# Altium schematic sheet eps_pv.SchDoc
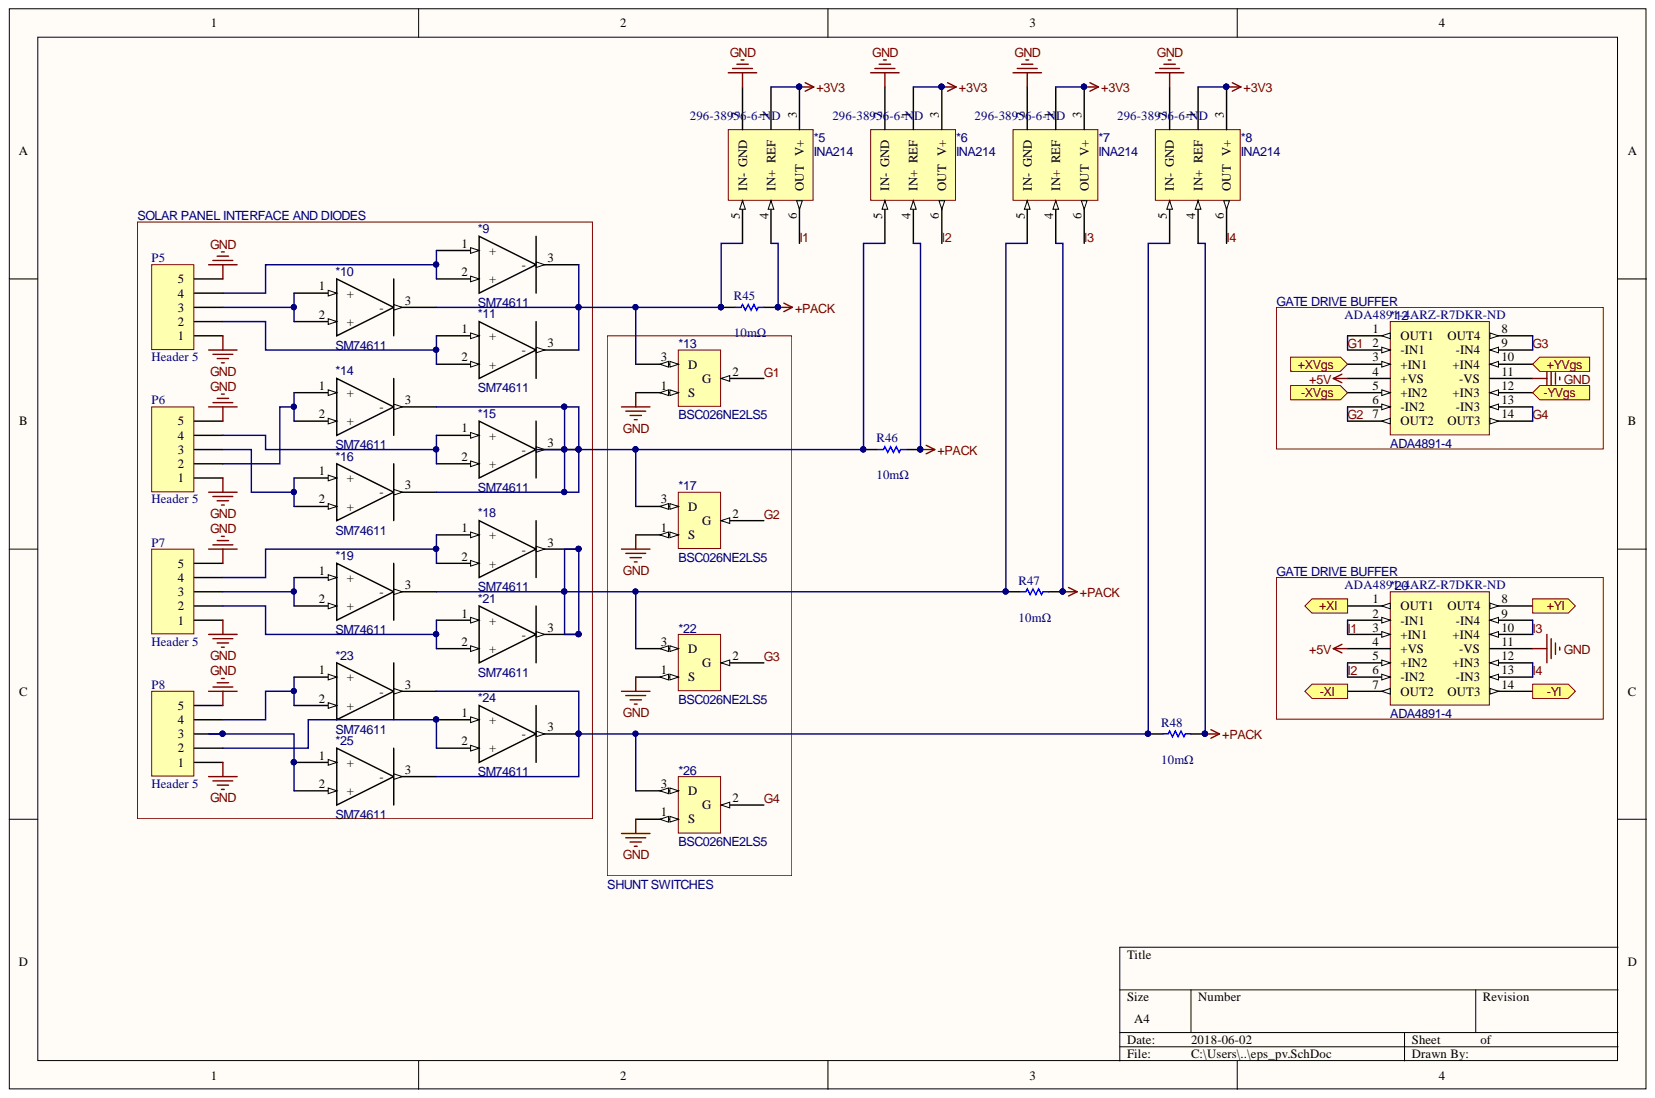
[diagram p0: eps_pv.SchDoc]

## Parts
*5  INA214  Supplier Part Number 1: 296-38956-6-ND  [SOT65P210X110-6N]
*6  INA214  Supplier Part Number 1: 296-38956-6-ND  [SOT65P210X110-6N]
*7  INA214  Supplier Part Number 1: 296-38956-6-ND  [SOT65P210X110-6N]
*8  INA214  Supplier Part Number 1: 296-38956-6-ND  [SOT65P210X110-6N]
*9  SM74611  [TO254P1435X464-3N]
*10  SM74611  [TO254P1435X464-3N]
*11  SM74611  [TO254P1435X464-3N]
*12  ADA4891-4  Supplier Part Number 1: ADA4891-4ARZ-R7DKR-ND  [R_14]
*13  BSC026NE2LS5  [INF-PG-TDSON-8-1_V]
*14  SM74611  [TO254P1435X464-3N]
*15  SM74611  [TO254P1435X464-3N]
*16  SM74611  [TO254P1435X464-3N]
*17  BSC026NE2LS5  [INF-PG-TDSON-8-1_V]
*18  SM74611  [TO254P1435X464-3N]
*19  SM74611  [TO254P1435X464-3N]
*20  ADA4891-4  Supplier Part Number 1: ADA4891-4ARZ-R7DKR-ND  [R_14]
*21  SM74611  [TO254P1435X464-3N]
*22  BSC026NE2LS5  [INF-PG-TDSON-8-1_V]
*23  SM74611  [TO254P1435X464-3N]
*24  SM74611  [TO254P1435X464-3N]
*25  SM74611  [TO254P1435X464-3N]
*26  BSC026NE2LS5  [INF-PG-TDSON-8-1_V]
P5  Header 5  [DF14-4P-1.25H(55) - duplicate]
P6  Header 5  [DF14-4P-1.25H(55) - duplicate]
P7  Header 5  [DF14-4P-1.25H(55) - duplicate]
P8  Header 5  [DF14-4P-1.25H(55) - duplicate]
R45  value 10mΩ  [1608[0603]]  (hidden comment: Res1)
R46  value 10mΩ  [1608[0603]]  (hidden comment: Res1)
R47  value 10mΩ  [1608[0603]]  (hidden comment: Res1)
R48  value 10mΩ  [1608[0603]]  (hidden comment: Res1)

## Ports
+XI, +XVgs, +YI, +YVgs, -XI, -XVgs, -YI, -YVgs

## Nets
+3V3: *5.1(REF) *5.3(V+) *6.1(REF) *6.3(V+) *7.1(REF) *7.3(V+) *8.1(REF) *8.3(V+)
+5V: *12.4(+VS) *20.4(+VS)
+PACK: *5.4(IN+) *6.4(IN+) *7.4(IN+) *8.4(IN+) R45.2 R46.2 R47.2 R48.2
GND: *5.2(GND) *6.2(GND) *7.2(GND) *8.2(GND) *12.11(-VS) *13.1 *17.1 *20.11(-VS) *22.1 *26.1 P5.1 P5.5 P6.1 P6.5 P7.1 P7.5 P8.1 P8.5
G1: *12.1(OUT1) *12.2(-IN1) *13.2
G2: *12.6(-IN2) *12.7(OUT2) *17.2
G3: *12.8(OUT4) *12.9(-IN4) *22.2
G4: *12.13(-IN3) *12.14(OUT3) *26.2
I1: *5.6(OUT) *20.2(-IN1) *20.3(+IN1)
I2: *6.6(OUT) *20.5(+IN2) *20.6(-IN2)
I3: *7.6(OUT) *20.9(-IN4) *20.10(+IN4)
I4: *8.6(OUT) *20.12(+IN3) *20.13(-IN3)
Net*5_5: *5.5(IN-) *9.3 *10.3 *11.3 *13.3 R45.1
Net*6_5: *6.5(IN-) *14.3 *15.3 *16.3 *17.3 R46.1
Net*7_5: *7.5(IN-) *18.3 *19.3 *21.3 *22.3 R47.1
Net*8_5: *8.5(IN-) *23.3 *24.3 *25.3 *26.3 R48.1
Net*9_1: *9.1 *9.2 P5.4
Net*10_1: *10.1 *10.2 P5.3
Net*11_1: *11.1 *11.2 P5.2
Net*12_3: *12.3(+IN1)
Net*12_5: *12.5(+IN2)
Net*12_10: *12.10(+IN4)
Net*12_12: *12.12(+IN3)
Net*14_1: *14.1 *14.2 P6.2
Net*15_1: *15.1 *15.2 P6.4
Net*16_1: *16.1 *16.2 P6.3
Net*18_1: *18.1 *18.2 P7.4
Net*19_1: *19.1 *19.2 P7.3
Net*20_1: *20.1(OUT1)
Net*20_7: *20.7(OUT2)
Net*20_8: *20.8(OUT4)
Net*20_14: *20.14(OUT3)
Net*21_1: *21.1 *21.2 P7.2
Net*23_1: *23.1 *23.2 P8.4
Net*24_1: *24.1 *24.2 P8.2
Net*25_1: *25.1 *25.2 P8.3

## Library data of the parts
*5-*8 = INA214: Current monitor; Component Kind: Standard; Supplier 1: Digi-Key; Library Name: heron-general.IntLib
*9-*11 *14-*16 *18 *19 *21 *23-*25 = SM74611: PV Diode; Component Kind: Standard; Library Name: heron-general.IntLib
*12 *20 = ADA4891-4: Op-amps; Component Kind: Standard; Supplier 1: Digi-Key; Library Name: heron-general.IntLib
*13 *17 *22 *26 = BSC026NE2LS5: MOSFET; Component Kind: Standard; Library Name: heron-general.IntLib
P5-P8 = Header 5: Header, 5-Pin; Component Kind: Standard; LatestRevisionDate: 17-Jul-2002; LatestRevisionNote: Re-released for DXP Platform.; Library Name: Miscellaneous Connectors.IntLib; Signal Integrity: Connector; Publisher: Altium Limited
R45 = Res1: Resistor; Value: 10mΩ; Component Kind: Standard; LatestRevisionDate: 17-Jul-2002; LatestRevisionNote: Re-released for DXP Platform.; Library Name: Miscellaneous Devices.IntLib; PackageDescription: Axial Device, Thru-Hole; 2 Leads; 0.3 in Pin Spacing; PackageReference: AXIAL-0.3; Published: 8-Jun-2000; Signal Integrity: Res; Simulation: RESISTOR; Publisher: Altium Limited
R46-R48 = Res1: Resistor; Component Kind: Standard; LatestRevisionDate: 17-Jul-2002; LatestRevisionNote: Re-released for DXP Platform.; Library Name: Miscellaneous Devices.IntLib; PackageDescription: Axial Device, Thru-Hole; 2 Leads; 0.3 in Pin Spacing; PackageReference: AXIAL-0.3; Published: 8-Jun-2000; Signal Integrity: Res; Simulation: RESISTOR; Publisher: Altium Limited
